# Altium schematic sheet stm32_mcu.SchDoc
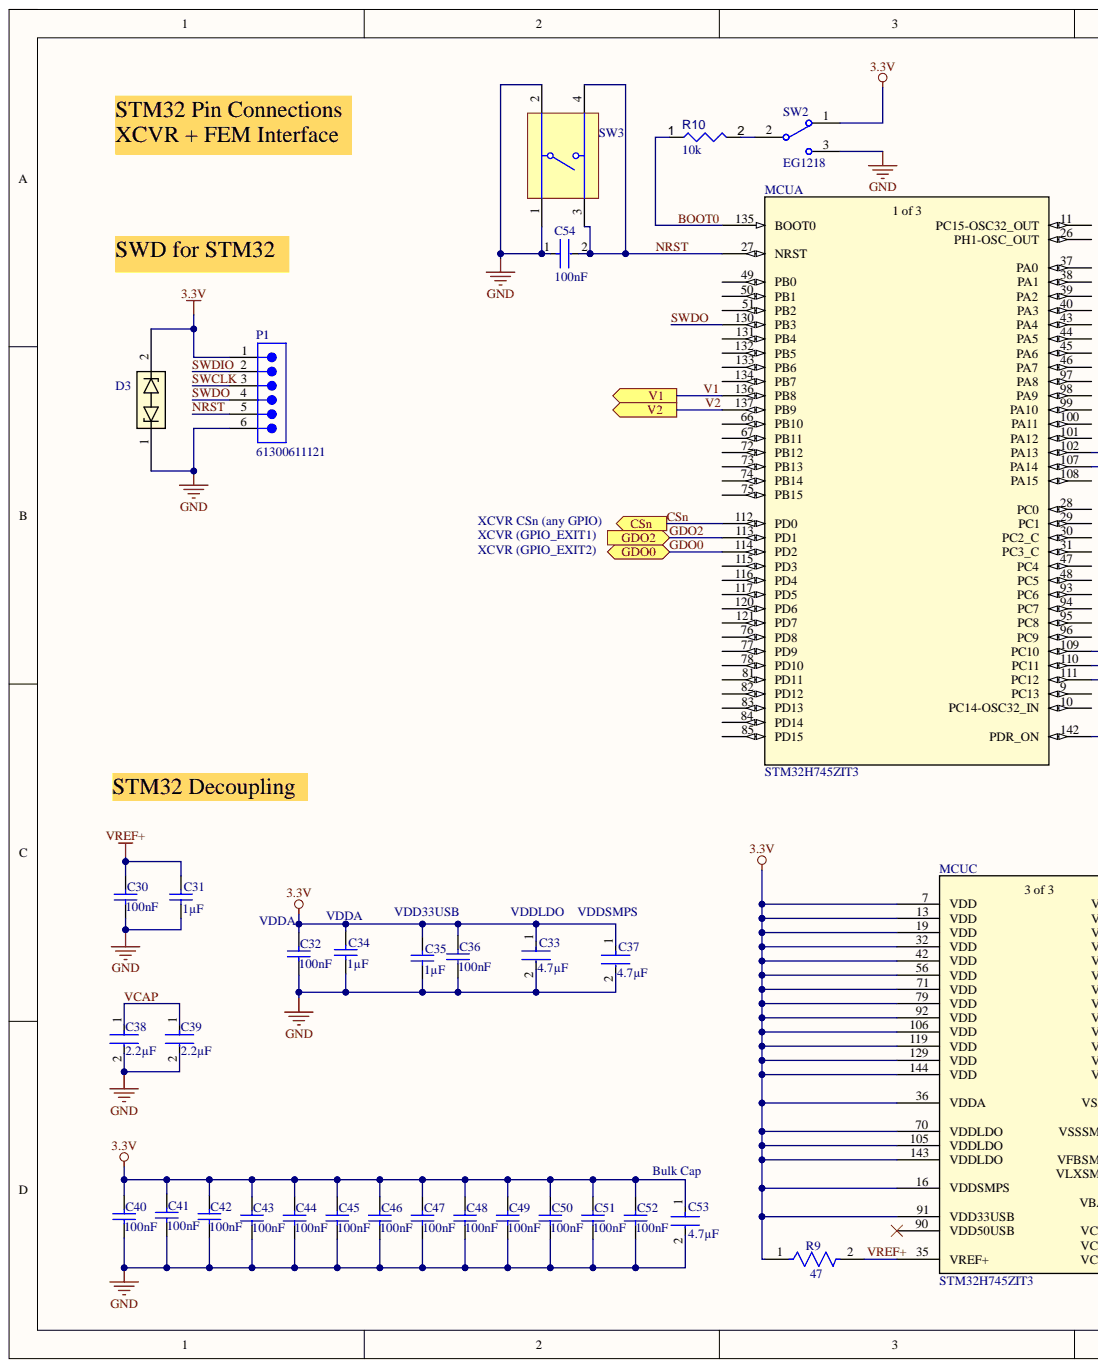
[diagram p0: stm32_mcu.SchDoc, left]
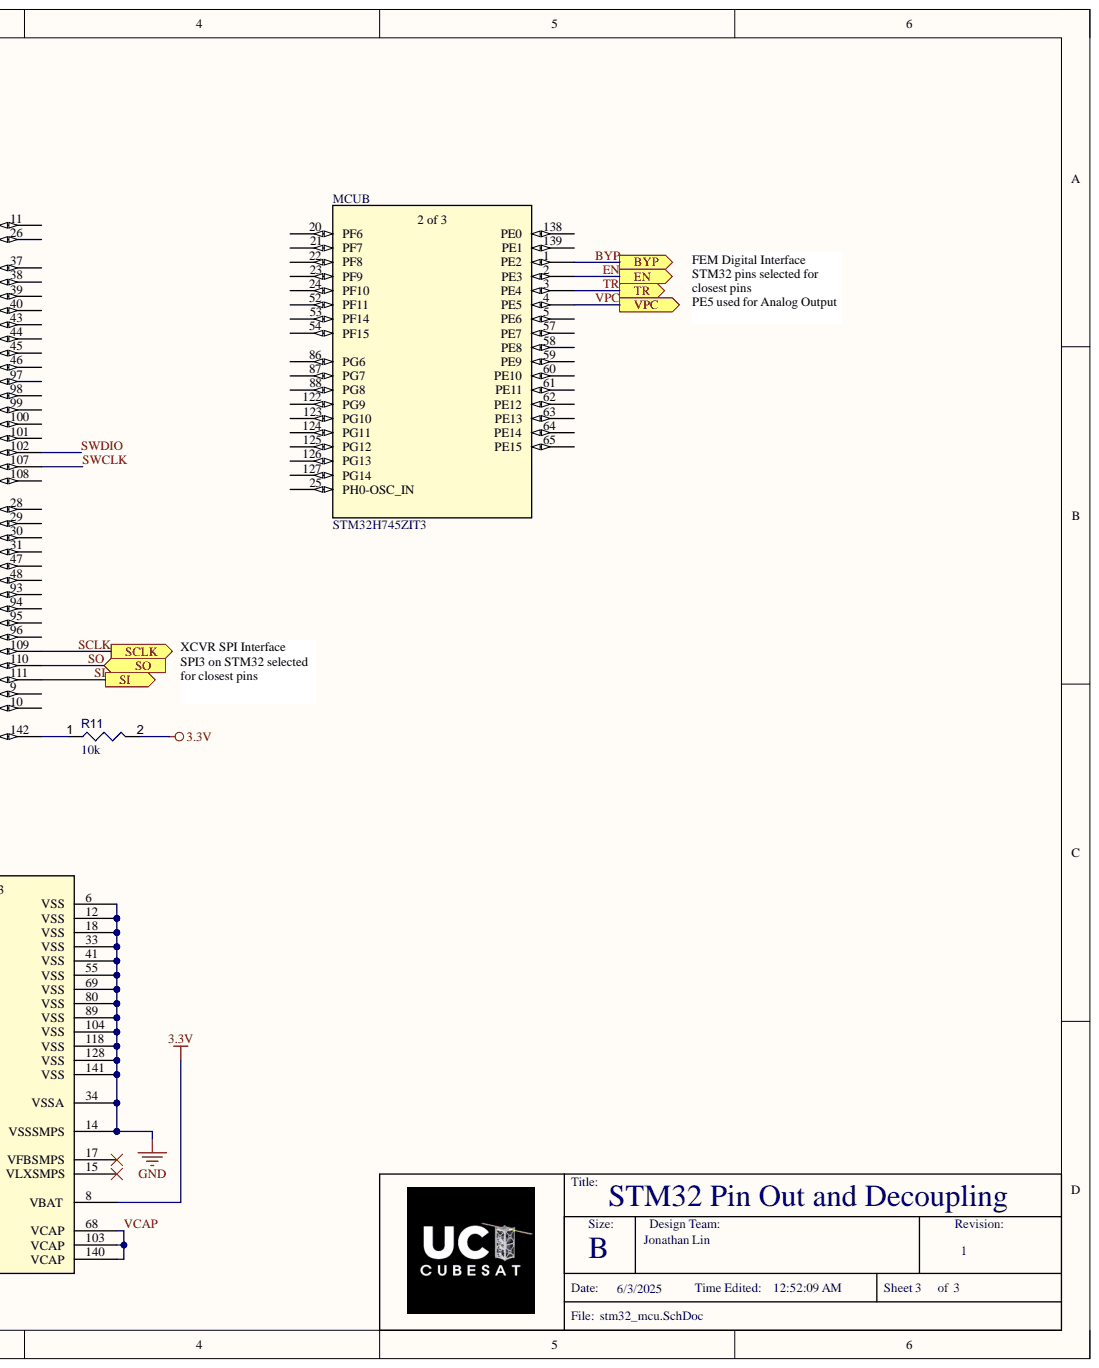
[diagram p1: stm32_mcu.SchDoc, right]

## Parts
C30  value 100nF  [CAPC2013X135X45ML10T25]  (hidden comment: GRM21BR72A104KAC4L)
C31  value 1µF  [CAPC2013X135X45LL10T25]  (hidden comment: GRM21BR71C105KA01L)
C32  value 100nF  [CAPC2013X135X45ML10T25]  (hidden comment: GRM21BR72A104KAC4L)
C33  value 4.7µF  [FP-GRM21B-0_2-e0_2_0_7-MFG]  (hidden comment: GRM21BZ7YA475KE15K)
C34  value 1µF  [CAPC2013X135X45LL10T25]  (hidden comment: GRM21BR71C105KA01L)
C35  value 1µF  [CAPC2013X135X45LL10T25]  (hidden comment: GRM21BR71C105KA01L)
C36  value 100nF  [CAPC2013X135X45ML10T25]  (hidden comment: GRM21BR72A104KAC4L)
C37  value 4.7µF  [FP-GRM21B-0_2-e0_2_0_7-MFG]  (hidden comment: GRM21BZ7YA475KE15K)
C38  value 2.2µF  [FP-GRM21B-0_15-IPC_A]  (hidden comment: GRM21BR71E225KE11L)
C39  value 2.2µF  [FP-GRM21B-0_15-IPC_A]  (hidden comment: GRM21BR71E225KE11L)
C40  value 100nF  [CAPC2013X135X45ML10T25]  (hidden comment: GRM21BR72A104KAC4L)
C41  value 100nF  [CAPC2013X135X45ML10T25]  (hidden comment: GRM21BR72A104KAC4L)
C42  value 100nF  [CAPC2013X135X45ML10T25]  (hidden comment: GRM21BR72A104KAC4L)
C43  value 100nF  [CAPC2013X135X45ML10T25]  (hidden comment: GRM21BR72A104KAC4L)
C44  value 100nF  [CAPC2013X135X45ML10T25]  (hidden comment: GRM21BR72A104KAC4L)
C45  value 100nF  [CAPC2013X135X45ML10T25]  (hidden comment: GRM21BR72A104KAC4L)
C46  value 100nF  [CAPC2013X135X45ML10T25]  (hidden comment: GRM21BR72A104KAC4L)
C47  value 100nF  [CAPC2013X135X45ML10T25]  (hidden comment: GRM21BR72A104KAC4L)
C48  value 100nF  [CAPC2013X135X45ML10T25]  (hidden comment: GRM21BR72A104KAC4L)
C49  value 100nF  [CAPC2013X135X45ML10T25]  (hidden comment: GRM21BR72A104KAC4L)
C50  value 100nF  [CAPC2013X135X45ML10T25]  (hidden comment: GRM21BR72A104KAC4L)
C51  value 100nF  [CAPC2013X135X45ML10T25]  (hidden comment: GRM21BR72A104KAC4L)
C52  value 100nF  [CAPC2013X135X45ML10T25]  (hidden comment: GRM21BR72A104KAC4L)
C53  value 4.7µF  [FP-GRM21B-0_2-e0_2_0_7-MFG]  (hidden comment: GRM21BZ7YA475KE15K)
C54  value 100nF  [FP-C0805C-DN-IPC_A]  (hidden comment: C0805C104K5RACTU)
D3  PESD3V3Z1BCSFYL  [SOD962-2_DSN0603-2_NEX]  (value not drawn)
MCU  STM32H745ZIT3  [LQFP-144]  (parts A,B,C)
P1  61300611121  [61300611121]
R9  value 47  [FP-RMCF1206-IPC_B]  (hidden comment: RMCF1206JT47R0)
R10  value 10k  [RESC3216X60X45ML15T20]  (hidden comment: Resistor 10k +/-1% 1206 250 mW)
R11  value 10k  [RESC3216X60X45ML15T20]  (hidden comment: Resistor 10k +/-1% 1206 250 mW)
SW2  EG1218  [ESWI-EG1218_V]
SW3  1825910-6 Tact Switch  [TECO-1825910-6_V]  (value not drawn)

## Ports
BYP, CSn, EN, GDO0, GDO2, SCLK, SI, SO, TR, V1, V2, VPC

## Nets
3.3V: C32.2 C33.1 C34.2 C35.2 C36.2 C37.1 C40.2 C41.2 C42.2 C43.2 C44.2 C45.2 C46.2 C47.2 C48.2 C49.2 C50.2 C51.2 C52.2 C53.1 D3.2 MCU.7(VDD) MCU.8(VBAT) MCU.13(VDD) MCU.16(VDDSMPS) MCU.19(VDD) MCU.32(VDD) MCU.36(VDDA) MCU.42(VDD) MCU.56(VDD) MCU.70(VDDLDO) MCU.71(VDD) MCU.79(VDD) MCU.91(VDD33USB) MCU.92(VDD) MCU.105(VDDLDO) MCU.106(VDD) MCU.119(VDD) MCU.129(VDD) MCU.143(VDDLDO) MCU.144(VDD) P1.1 R9.1 R11.2 SW2.1
GND: C30.1 C31.1 C32.1 C33.2 C34.1 C35.1 C36.1 C37.2 C38.2 C39.2 C40.1 C41.1 C42.1 C43.1 C44.1 C45.1 C46.1 C47.1 C48.1 C49.1 C50.1 C51.1 C52.1 C53.2 C54.1 D3.1 MCU.6(VSS) MCU.12(VSS) MCU.14(VSSSMPS) MCU.18(VSS) MCU.33(VSS) MCU.34(VSSA) MCU.41(VSS) MCU.55(VSS) MCU.69(VSS) MCU.80(VSS) MCU.89(VSS) MCU.104(VSS) MCU.118(VSS) MCU.128(VSS) MCU.141(VSS) P1.6 SW2.3 SW3.1 SW3.2
BOOT0: MCU.135(BOOT0) R10.1
BYP: MCU.1(PE2) ; port BYP
CSn: MCU.112(PD0) ; port CSn
EN: MCU.2(PE3) ; port EN
GDO0: MCU.114(PD2) ; port GDO0
GDO2: MCU.113(PD1) ; port GDO2
NRST: C54.2 MCU.27(NRST) P1.5 SW3.3 SW3.4
SCLK: MCU.109(PC10) ; port SCLK
SI: MCU.111(PC12) ; port SI
SO: MCU.110(PC11) ; port SO
SWCLK: MCU.107(PA14) P1.3
SWDIO: MCU.102(PA13) P1.2
SWDO: MCU.130(PB3) P1.4
TR: MCU.3(PE4) ; port TR
V1: MCU.136(PB8) ; port V1
V2: MCU.137(PB9) ; port V2
VCAP: C38.1 C39.1 MCU.68(VCAP) MCU.103(VCAP) MCU.140(VCAP)
VPC: MCU.4(PE5) ; port VPC
VREF+: C30.2 C31.2 MCU.35(VREF+) R9.2
NetMCU_142: MCU.142(PDR_ON) R11.1
NetR10_2: R10.2 SW2.2

Not connected: MCU 5(PE6) 9(PC13) 10(PC14-OSC32_IN) 11(PC15-OSC32_OUT) 15(VLXSMPS) 17(VFBSMPS) 20(PF6) 21(PF7) 22(PF8) 23(PF9) 24(PF10) 25(PH0-OSC_IN) 26(PH1-OSC_OUT) 28(PC0) 29(PC1) 30(PC2_C) 31(PC3_C) 37(PA0) 38(PA1) 39(PA2) 40(PA3) 43(PA4) 44(PA5) 45(PA6) 46(PA7) 47(PC4) 48(PC5) 49(PB0) 50(PB1) 51(PB2) 52(PF11) 53(PF14) 54(PF15) 57(PE7) 58(PE8) 59(PE9) 60(PE10) 61(PE11) 62(PE12) 63(PE13) 64(PE14) 65(PE15) 66(PB10) 67(PB11) 72(PB12) 73(PB13) 74(PB14) 75(PB15) 76(PD8) 77(PD9) 78(PD10) 81(PD11) 82(PD12) 83(PD13) 84(PD14) 85(PD15) 86(PG6) 87(PG7) 88(PG8) 90(VDD50USB) 93(PC6) 94(PC7) 95(PC8) 96(PC9) 97(PA8) 98(PA9) 99(PA10) 100(PA11) 101(PA12) 108(PA15) 115(PD3) 116(PD4) 117(PD5) 120(PD6) 121(PD7) 122(PG9) 123(PG10) 124(PG11) 125(PG12) 126(PG13) 127(PG14) 131(PB4) 132(PB5) 133(PB6) 134(PB7) 138(PE0) 139(PE1)

## Library data of the parts
C30 C32 C36 C40-C52 = CMP-001-00124-1: Ceramic Capacitor, Multilayer, Ceramic, 100V, 10% +Tol, 10% -Tol, X7R, 15% TC, 0.1uF, Surface Mount, 0805; Multilayer: Yes; Capacitor Type: Ceramic; Case/Package: 0805; Component Kind: Standard; Dielectric Material: Ceramic; Height: 1.25mm; JESD-609 Code: e3; Length: 2mm; Max Operating Temperature: 125°C; Min Operating Temperature: -55°C; Mounting Technology: Surface Mount; Package Shape: Rectangular; Package Style: SMTMeter; Packaging: Tape and Reel; Pins: 2; Rated DC Voltage (URdc): 100V; Reach SVHC Compliant: Yes; RoHS Compliant: Yes; Temperature Characteristics Code: X7R; Terminal Finish: Nickel; Termination: Wraparound; Tolerance  (fill in as +-): 10%; Tolerance (fill in as +-): 10%; Width: 1.25mm; Library Name: University of California Irvine
C31 C34 C35 = CMP-001-00129-1: Ceramic Capacitor, Multilayer, Ceramic, 16V, 10% +Tol, 10% -Tol, X7R, 15% TC, 1uF, Surface Mount, 0805; Multilayer: Yes; Capacitor Type: Ceramic; Case/Package: 0805; Component Kind: Standard; Dielectric Material: Ceramic; Height: 1.25mm; JESD-609 Code: e3; Length: 2mm; Max Operating Temperature: 125°C; Min Operating Temperature: -55°C; Mounting Technology: Surface Mount; Package Shape: Rectangular; Package Style: SMTMeter; Packaging: Tape and Reel; Pins: 2; Rated DC Voltage (URdc): 16V; Reach SVHC Compliant: Yes; RoHS Compliant: Yes; Temperature Characteristics Code: X7R; Terminal Finish: Nickel; Termination: Wraparound; Tolerance  (fill in as +-): 10%; Tolerance (fill in as +-): 10%; Width: 1.25mm; Library Name: University of California Irvine
C33 C37 C53 = CMP-001-00127-1: Ceramic Capacitor, Multilayer, Ceramic, 35V, 10% +Tol, 10% -Tol, X7R, 15% TC, 4.7uF, Surface Mount, 0805; Multilayer: Yes; Capacitor Type: Ceramic; Case/Package: 0805; Component Kind: Standard; Dielectric Material: Ceramic; JESD-609 Code: e3; Max Operating Temperature: 125°C; Min Operating Temperature: -55°C; Mounting Technology: Surface Mount; Package Shape: Rectangular; Packaging: Tape and Reel; Pins: 2; Rated DC Voltage (URdc): 35V; Reach SVHC Compliant: Yes; RoHS Compliant: Yes; Temperature Characteristics Code: X7R; Terminal Finish: Nickel; Termination: Wraparound; Tolerance  (fill in as +-): 10%; Tolerance (fill in as +-): 10%; Library Name: University of California Irvine
C38 C39 = CMP-001-00131-1: Ceramic Capacitor, Multilayer, Ceramic, 25V, 10% +Tol, 10% -Tol, X7R, 15% TC, 2.2uF, Surface Mount, 0805; Multilayer: Yes; Capacitor Type: Ceramic; Case/Package: 0805; Component Kind: Standard; Dielectric Material: Ceramic; Height: 1.25mm; JESD-609 Code: e3; Length: 2mm; Max Operating Temperature: 125°C; Min Operating Temperature: -55°C; Mounting Technology: Surface Mount; Package Shape: Rectangular; Package Style: SMTMeter; Packaging: Tape and Reel; Pins: 2; Rated DC Voltage (URdc): 25V; Reach SVHC Compliant: Yes; RoHS Compliant: Yes; Temperature Characteristics Code: X7R; Terminal Finish: Nickel; Termination: Wraparound; Tolerance  (fill in as +-): 10%; Tolerance (fill in as +-): 10%; Width: 1.25mm; Library Name: University of California Irvine
C54 = CMP-001-00137-1: Ceramic Capacitor, Multilayer, Ceramic, 50V, 10% +Tol, 10% -Tol, X7R, 15% TC, 0.1uF, Surface Mount, 0805; Multilayer: Yes; Capacitor Type: Ceramic; Case/Package: 0805; Component Kind: Standard; Dielectric Material: Ceramic; Height: 0.78mm; JESD-609 Code: e3; Length: 2mm; Max Operating Temperature: 125°C; Min Operating Temperature: -55°C; Mounting Technology: Surface Mount; Package Shape: Rectangular; Package Style: SMTMeter; Packaging: Tape and Reel; Pins: 2; Rated DC Voltage (URdc): 50V; Reach SVHC Compliant: Yes; RoHS Compliant: Yes; Temperature Characteristics Code: X7R; Terminal Finish: Nickel; Termination: Wraparound; Tolerance  (fill in as +-): 10%; Tolerance (fill in as +-): 10%; Width: 1.25mm; Library Name: University of California Irvine
D3 = CMP-004-00036-1: PESD3V3Zxx: 6.8 V 15 A 0.45 pF Bidirectional ESD Protection Diode - DSN0603-2; Component Kind: Standard; Library Name: University of California Irvine
MCU = CMP-058-000001-2: No Description Available; Mfr_Name: STMicroelectronics; Component Kind: Standard; Design Item ID: STM32H745ZIT3; RefDes: RefDes; Source: STM32H745ZIT3.SchLib; Type: Type; Library Name: University of California Irvine
P1 = CMP-002-00073-1: Board Connector, 6 Contact(s), 1 Row(s), Male, Straight, 0.1 inch Pitch, Solder Terminal, Locking, Black Insulator, Plug for STM32 interface; Body Length: 0.6inch; Contact Pattern: RECTANGULAR; Contact Resistance: 20mR; Contact Style: SQ PIN-SKT; Dielectric Withstanding Voltage (AC): 500V; Insulation Resistance: 1GR; Number Of Connectors: 1; Number of Contacts: 6; Rated Current (Signal): 3A; Reference Standard: UL; Reliability: COMMERCIAL; Body Breadth: 0.1inch; Body Depth: 0.1inch; Body/Shell Style: PLUG; Component Kind: Standard; Connector Type: BOARD CONNECTOR; Contact Finish - Mating: GOLD; Contact Finish - Termination: Gold (Au) - with Nickel (Ni) barrier; Contact Gender: Male; Contact Material: Copper Alloy; Insulation Color: Black; Insulation Material: Polybutylene; JESD-609 Code: e4; Mating Contact Pitch: 0.1inch; Max Operating Temperature: 125°C; Min Operating Temperature: -40°C; Mounting Option 1: LOCKING; Mounting Style: STRAIGHT; Mounting Technology: Board; Number of Rows Loaded: 1; PCB Contact Pattern: RECTANGULAR; Reach SVHC Compliant: Yes; RoHS Compliant: Yes; Terminal Length: 0.118inch; Terminal Pitch: 2.54mm; Termination: Solder; Library Name: University of California Irvine
R9 = CMP-009-00201-1: Fixed Resistor, Metal Glaze/thick Film, 0.25W, 47ohm, 200V, 5% +/-Tol, 200ppm/Cel, Surface Mount, 1206; Package Height: 0.55mm; Package Length: 3.2mm; Package Width: 1.6mm; Power: 250mW; Rated Temperature: 70°C; Reference Standard: AEC-Q200; Temperature Coefficient (ppm/Cel): 200; Case/Package: 1206; Component Kind: Standard; Construction: Rectangular; JESD-609 Code: e3; Max Operating Temperature: 155°C; Min Operating Temperature: -55°C; Mounting Technology: Surface Mount; Package Shape: Rectangular; Packaging: Tape and Reel; Pins: 2; Reach SVHC Compliant: Yes; Resistor Type: FIXED RESISTOR; RoHS Compliant: Yes; Technology: METAL GLAZE/THICK FILM; Terminal Finish: Nickel; Termination: Wraparound; Tolerance: 5%; Working Voltage: 200V; Library Name: University of California Irvine
R10 R11 = CMP-009-00016-5: Chip Resistor, 10 KOhm, +/- 1%, 0.25 W, -55 to 155 degC, 1206 (3216 Metric); Power: 250mW; Voltage Rating: 200V; Case/Package: 1206; Component Kind: Standard; Max Operating Temperature: 155°C; Min Operating Temperature: -55°C; Mounting Technology: SM; Pins: 2; RoHS Compliant: True; Tolerance: 1%; Library Name: University of California Irvine
SW2 = CMP-011-00006-2: Slide Switch, SPDT, 500 V, -20 to 70 degC, 3-Pin THD, RoHS, Bulk; Manufacturer: E-Switch; Contact Current Rating: 200mA; Operating Force: 250gf; Voltage Rating (AC): 30V; Case/Package: EG1218; Component Kind: Standard; Contact Finish: Silver; Contact Material: Copper; Contact Plating: Silver; Current Rating: 200mA; Datasheet URL: https://www.e-switch.com/system/asset/product_line/data_sheet/119/EG.pdf; Datasheet Version: Oct-15; Manufacturer URL: http://www.e-switch.ch/en/; Max Operating Temperature: 70°C; Min Operating Temperature: -20°C; Mounting Technology: TH; Package Description: 3-Pin Through Hole Device, Body 11.6 x 4 mm, Pitch 2.5 mm; Package Quantity: 1000; Package Reference: EG1218; Packaging: Bulk; Pins: 3; RoHS Compliant: True; Throw Configuration: SPDT; Voltage Rating (DC): 30V; Library Name: University of California Irvine
SW3 = CMP-011-00013-1: Keypad Switch, 1 Switches, SPST, Momentary-tactile, 0.05A, 24VDC, 2.06N, 4 PCB Hole Cnt, Solder Terminal, Through Hole-straight; Body Breadth: 5.99mm; Body Height: 3.61mm; Circuit Configuration: 1X1; Contact Current(DC)-Max: 50mA; Contact DC Max Rating R Load: .05A@24VDC; Contact Voltage(DC)-Max: 24V; Number of Switches: 1; Body Length or Diameter: 5.99mm; Component Kind: Standard; Max Operating Temperature: 85°C; Min Operating Temperature: -35°C; Mounting Technology: Through Hole; Operating Force-Max: 2.06N; Reach SVHC Compliant: Yes; RoHS Compliant: Yes; Switch Action: MOMENTARY; Switch Function: SPST; Switch Type: Keypad; Termination: Solder; Library Name: University of California Irvine
